# Altium schematic sheet Controller.SchDoc(Controller)
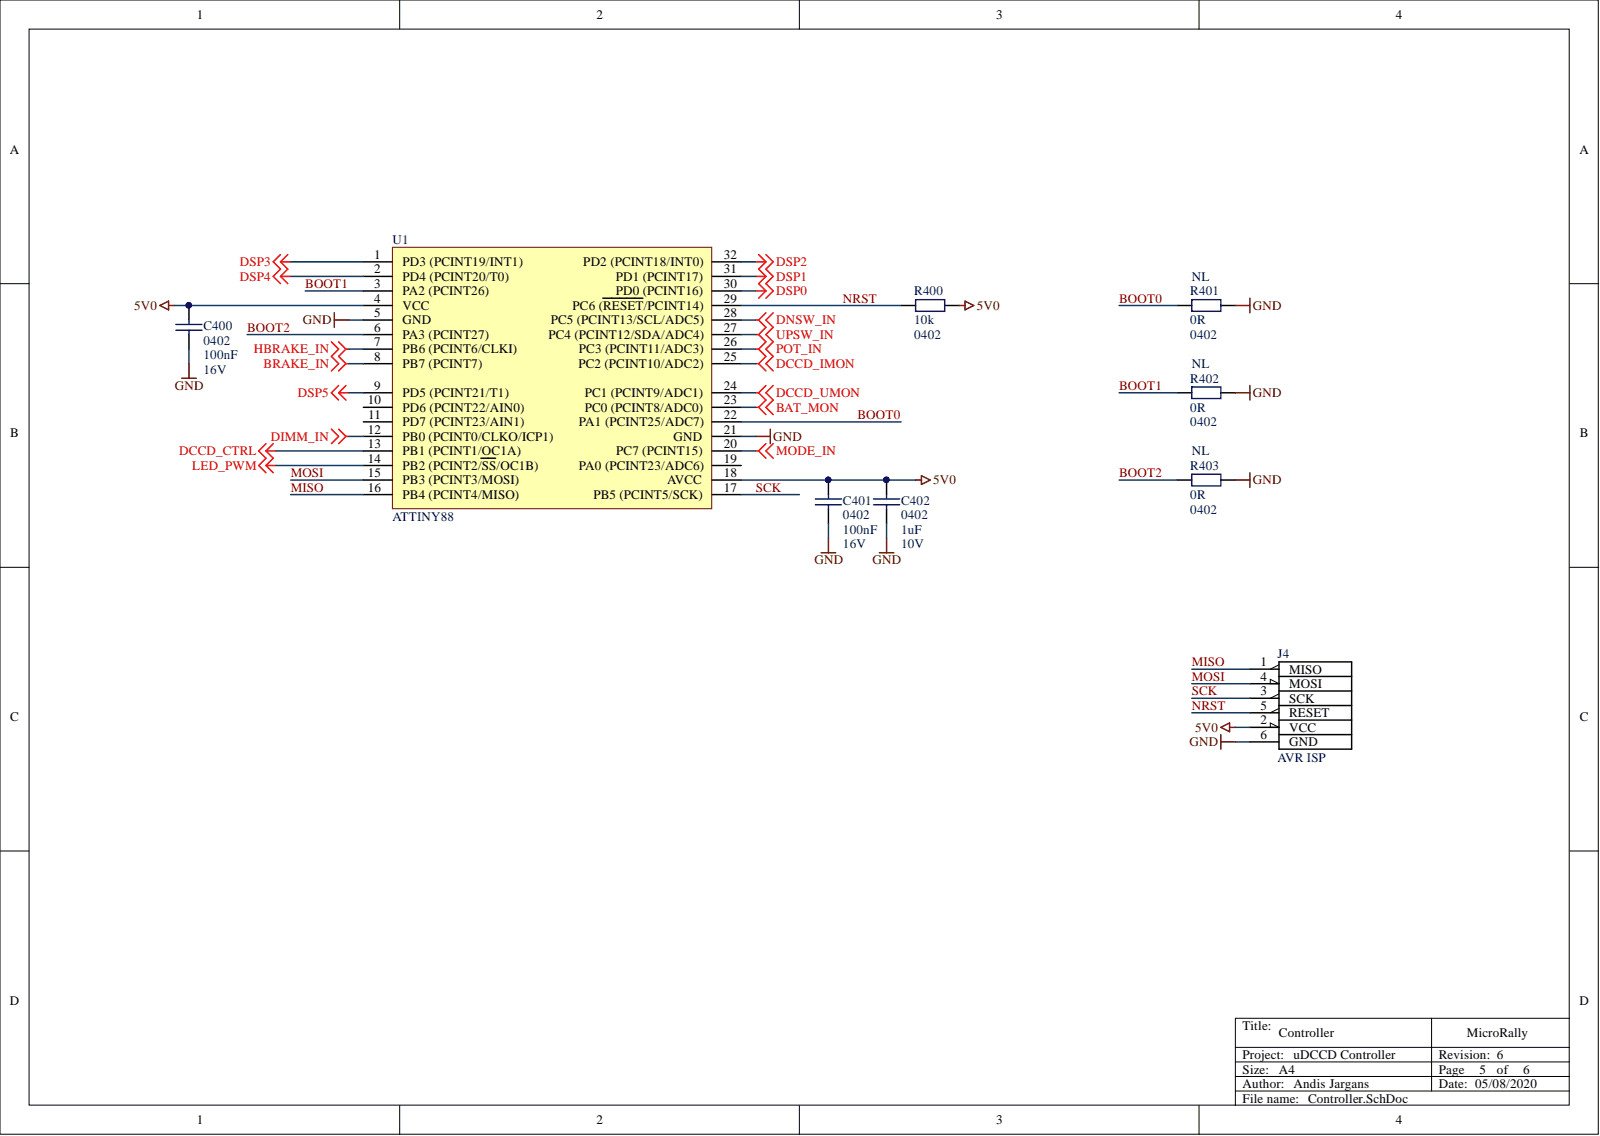
[diagram p0: Controller.SchDoc]

## Parts
C400  100n  value 100nF  PACKAGE: 0402  _VOLTAGE: 16V  [C 0402]
C401  100n  value 100nF  PACKAGE: 0402  _VOLTAGE: 16V  [C 0402]
C402  1u  value 1uF  PACKAGE: 0402  _VOLTAGE: 10V  [C 0402]
J4  AVR ISP  [P2.54 2x3 TH]  (no BOM)
R400  10k  PACKAGE: 0402  [R 0402]
R401  0  value 0R  PLACE: NL  PACKAGE: 0402  [R 0402]
R402  0  value 0R  PLACE: NL  PACKAGE: 0402  [R 0402]
R403  0  value 0R  PLACE: NL  PACKAGE: 0402  [R 0402]
U1  ATTINY88  [TQFP32]

## Nets
5V0: C400.1 C401.1 C402.1 J4.2(VCC) R400.2 U1.4(VCC) U1.18(AVCC)
BAT_MON: U1.23(PC0_(PCINT8/ADC0))
BRAKE_IN: U1.8(PB7_(PCINT7))
DCCD_CTRL: U1.13(PB1_(PCINT1/OC1A))
DCCD_IMON: U1.25(PC2_(PCINT10/ADC2))
DCCD_UMON: U1.24(PC1_(PCINT9/ADC1))
DIMM_IN: U1.12(PB0_(PCINT0/CLKO/ICP1))
DNSW_IN: U1.28(PC5_(PCINT13/SCL/ADC5))
DSP0: U1.30(PD0_(PCINT16))
DSP1: U1.31(PD1_(PCINT17))
DSP2: U1.32(PD2_(PCINT18/INT0))
DSP3: U1.1(PD3_(PCINT19/INT1))
DSP4: U1.2(PD4_(PCINT20/T0))
DSP5: U1.9(PD5_(PCINT21/T1))
GND: C400.2 C401.2 C402.2 J4.6(GND) R401.2 R402.2 R403.2 U1.5(GND) U1.21(GND)
HBRAKE_IN: U1.7(PB6_(PCINT6/CLKI))
LED_PWM: U1.14(PB2_(PCINT2/SS/OC1B))
MODE_IN: U1.20(PC7_(PCINT15))
POT_IN: U1.26(PC3_(PCINT11/ADC3))
UPSW_IN: U1.27(PC4_(PCINT12/SDA/ADC4))
BOOT0: R401.1 U1.22(PA1_(PCINT25/ADC7))
BOOT1: R402.1 U1.3(PA2_(PCINT26))
BOOT2: R403.1 U1.6(PA3_(PCINT27))
MISO: J4.1(MISO) U1.16(PB4_(PCINT4/MISO))
MOSI: J4.4(MOSI) U1.15(PB3_(PCINT3/MOSI))
NRST: J4.5(RESET) R400.1 U1.29(PC6_(RESET/PCINT14))
SCK: J4.3(SCK) U1.17(PB5_(PCINT5/SCK))

Not connected: U1 10(PD6_(PCINT22/AIN0)) 11(PD7_(PCINT23/AIN1)) 19(PA0_(PCINT23/ADC6))

## Library data of the parts
C400 C401 = C_100_16V_0402: Capacitor 0402 100nF 16V; _TOLERANCE: 10%; Library Name: Capacitors Ceramic.IntLib; Component Kind: Standard; _CAPACITANCE: 100nF
C402 = C_1000_10V_0402: Capacitor 0402 1uF 10V; _TOLERANCE: 10%; Library Name: Capacitors Ceramic.IntLib; Component Kind: Standard; _CAPACITANCE: 1uF
J4 = AVR ISP 6pin 2.54mm: AVT ISP Instalation header, 2.54mm pitch; Library Name: Installation_Headers.IntLib
R400 = R_10000_0402: Resistor 0402 10k 1/16W; _TOLERANCE: 1%; _POWER: 62.5mW; VALUE: 10k; Library Name: Resistors Chip.IntLib; Component Kind: Standard
R401-R403 = R_0_0402: Resistor 0402 0R 1/16W; _TOLERANCE: 1%; _POWER: 62.5mW; Library Name: Resistors Chip.IntLib; Component Kind: Standard
U1 = ATTINY88-AU: Atmel ATTINY88 LQFP32; _VOLTAGE: 1.8-5.5V; _FRQUENCY: 12MHz; _FLASH: 8kB; _EEPROM: 64B; _CPU: AVR; VALUE: ATTINY88; PART#: ATTINY88-AU; PACKAGE: TQFP32; Library Name: MCU.IntLib; Component Kind: Standard
